# Altium schematic sheet mike_piezo.SchDoc
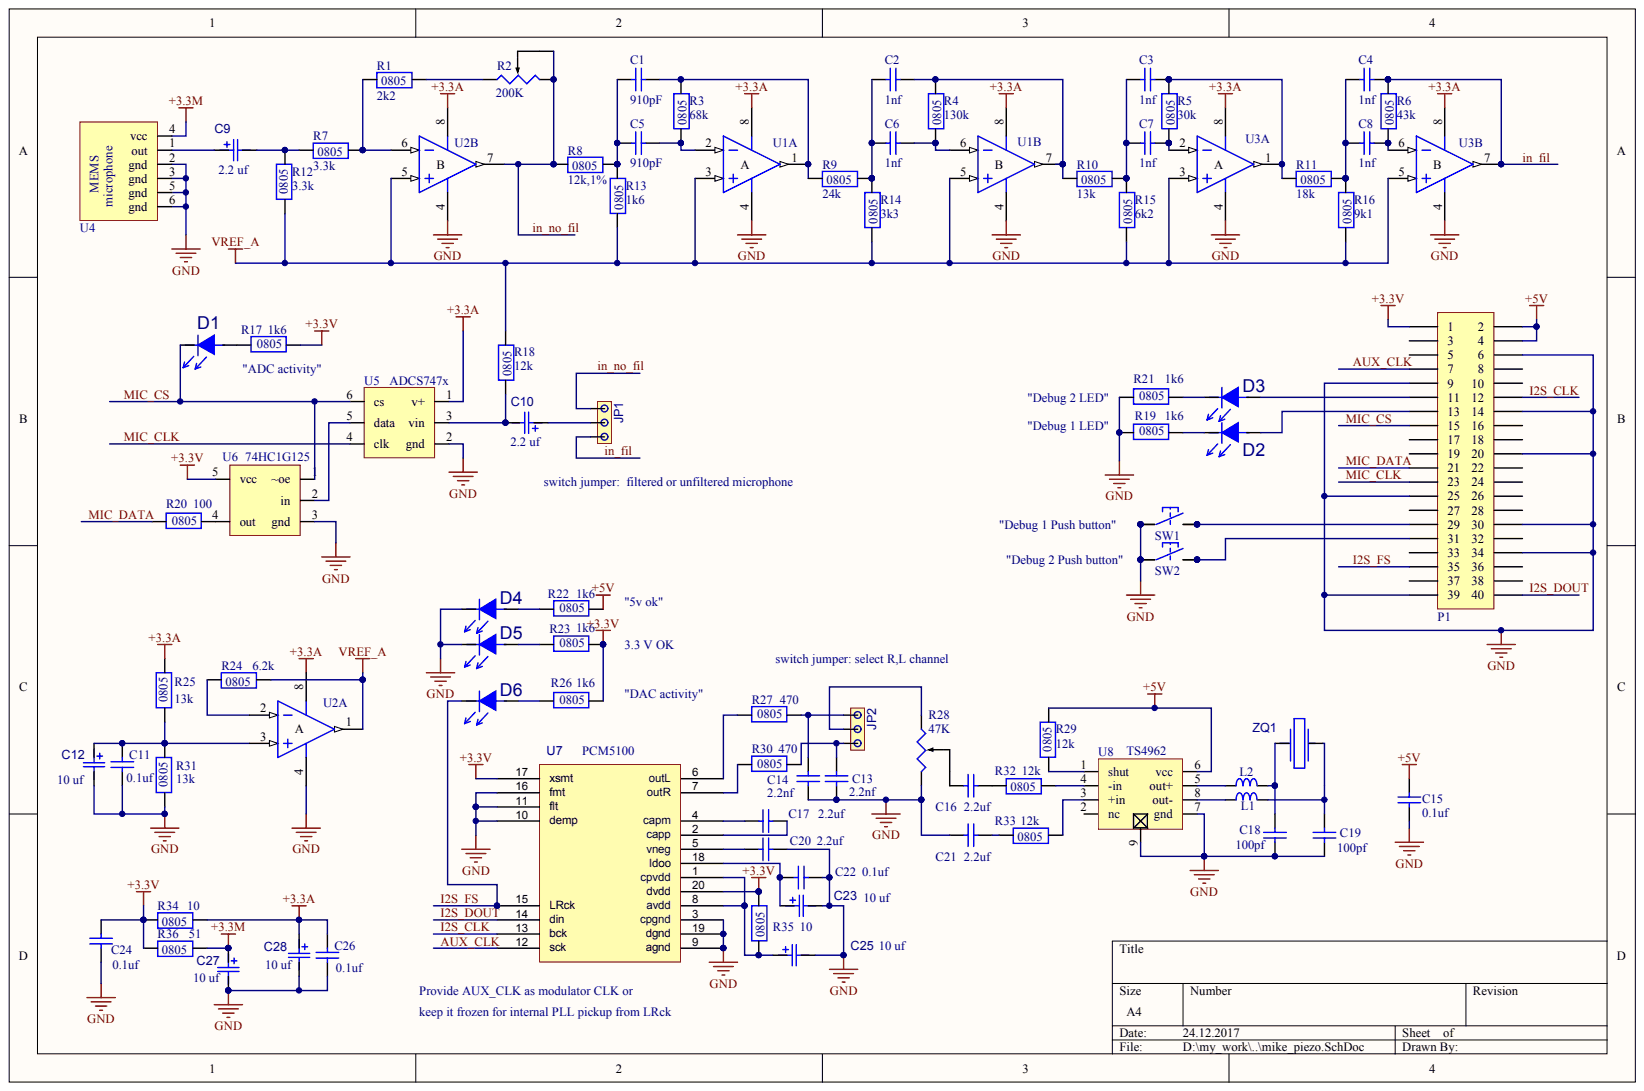
[diagram p0: mike_piezo.SchDoc]

## Parts
C1  value 910pF  (hidden comment: cap0805)
C2  value 1nf  (hidden comment: cap0805)
C3  value 1nf  (hidden comment: cap0805)
C4  value 1nf  (hidden comment: cap0805)
C5  value 910pF  (hidden comment: cap0805)
C6  value 1nf  (hidden comment: cap0805)
C7  value 1nf  (hidden comment: cap0805)
C8  value 1nf  (hidden comment: cap0805)
C9  CAP_SMT_d4x5.4  (value not drawn)
C10  CAP_SMT_d4x5.4  (value not drawn)
C11  value 0.1uf  (hidden comment: cap0805)
C12  CAP_POL_SMA  (value not drawn)
C13  value 2.2nf  (hidden comment: cap0805)
C14  value 2.2nf  (hidden comment: cap0805)
C15  value 0.1uf  (hidden comment: cap0805)
C16  value 2.2uf  (hidden comment: cap0805)
C17  value 2.2uf  (hidden comment: cap0805)
C18  value 100pf  (hidden comment: cap0805)
C19  value 100pf  (hidden comment: cap0805)
C20  value 2.2uf  (hidden comment: cap0805)
C21  value 2.2uf  (hidden comment: cap0805)
C22  value 0.1uf  (hidden comment: cap0805)
C23  CAP_POL_SMA  (value not drawn)
C24  value 0.1uf  (hidden comment: cap0805)
C25  CAP_POL_SMA  (value not drawn)
C26  value 0.1uf  (hidden comment: cap0805)
C27  CAP_POL_SMA  (value not drawn)
C28  CAP_POL_SMA  (value not drawn)
D1  LIGHT_SM0805  (value not drawn)
D2  LIGHT_SM0805  (value not drawn)
D3  LIGHT_SM0805  (value not drawn)
D4  LIGHT_SM0805  (value not drawn)
D5  LIGHT_SM0805  (value not drawn)
D6  LIGHT_SM0805  (value not drawn)
JP1  JUMPER3  (value not drawn)
JP2  JUMPER3  (value not drawn)
L1  75R@100MHz  [INDC2012X105N]  (value not drawn)
L2  75R@100MHz  [INDC2012X105N]  (value not drawn)
P1  2x20 2.54mm vertical  (value not drawn)
R1  value 2k2  (hidden comment: res0805_EU)
R2  value 200K  (hidden comment: res_var_SMT)
R3  value 68k  (hidden comment: res0805_EU)
R4  value 130k  (hidden comment: res0805_EU)
R5  value 30k  (hidden comment: res0805_EU)
R6  value 43k  (hidden comment: res0805_EU)
R7  value 3.3k  (hidden comment: res0805_EU)
R8  value 12k,1%  (hidden comment: res0805_EU)
R9  value 24k  (hidden comment: res0805_EU)
R10  value 13k  (hidden comment: res0805_EU)
R11  value 18k  (hidden comment: res0805_EU)
R12  value 3.3k  (hidden comment: res0805_EU)
R13  value 1k6  (hidden comment: res0805_EU)
R14  value 3k3  (hidden comment: res0805_EU)
R15  value 6k2  (hidden comment: res0805_EU)
R16  value 9k1  (hidden comment: res0805_EU)
R17  value 1k6  (hidden comment: res0805_EU)
R18  value 12k  (hidden comment: res0805_EU)
R19  value 1k6  (hidden comment: res0805_EU)
R20  value 100  (hidden comment: res0805_EU)
R21  value 1k6  (hidden comment: res0805_EU)
R22  value 1k6  (hidden comment: res0805_EU)
R23  value 1k6  (hidden comment: res0805_EU)
R24  value 6.2k  (hidden comment: res0805_EU)
R25  value 13k  (hidden comment: res0805_EU)
R26  value 1k6  (hidden comment: res0805_EU)
R27  value 470  (hidden comment: res0805_EU)
R28  value 47K  (hidden comment: res_var_SMT)
R29  value 12k  (hidden comment: res0805_EU)
R30  value 470  (hidden comment: res0805_EU)
R31  value 13k  (hidden comment: res0805_EU)
R32  value 12k  (hidden comment: res0805_EU)
R33  value 12k  (hidden comment: res0805_EU)
R34  value 10  (hidden comment: res0805_EU)
R35  value 10  (hidden comment: res0805_EU)
R36  value 51  (hidden comment: res0805_EU)
SW1  Button 6x6 SMT  (value not drawn)
SW2  Button 6x6 SMT  (value not drawn)
U1  AD8572ARZ  (value not drawn)  (parts A,B)
U2  AD8572ARZ  (value not drawn)  (parts A,B)
U3  AD8572ARZ  (value not drawn)  (parts A,B)
U4  microphone
U5  ADCS747x
U6  74HC1G125
U7  value PCM5100  (hidden comment: PCM510x)
U8  TS4962
ZQ1  Piezo  (value not drawn)

## Nets
+3.3A: C26.2 C28.1 R25.2 R34.1 U1.8 U2.8 U3.8 U5.1(v+)
+3.3M: C27.1 R36.1 U4.4(vcc)
+3.3V: C24.2 P1.1 R17.2 R23.2 R26.2 R34.2 R35.1 R36.2 U6.5(vcc) U7.17(xsmt) U7.20(dvdd)
+5V: C15.2 P1.2 P1.4 R22.2 R29.2 U8.6(vcc)
GND: C11.1 C12.2 C13.1 C14.1 C15.1 C18.1 C19.1 C20.2 C21.1 C22.2 C23.2 C24.1 C25.2 C26.1 C27.2 C28.2 D4.2 D5.2 P1.6 P1.9 P1.14 P1.20 P1.25 P1.30 P1.34 P1.39 R19.1 R21.1 R28.3 R31.1 SW1.1 SW1.2 SW2.1 SW2.2 U1.4 U2.4 U3.4 U4.2(gnd) U4.3(gnd) U4.5(gnd) U4.6(gnd) U5.2(gnd) U6.3(gnd) U7.3(cpgnd) U7.9(agnd) U7.10(demp) U7.11 U7.16(fmt) U7.19(dgnd) U8.7(gnd) U8.9
VREF_A: R12.1 R13.2 R14.2 R15.2 R16.2 R18.1 R24.1 U1.3 U1.5 U2.1 U2.5 U3.3 U3.5
AUX_CLK: P1.7 U7.12(sck)
I2S_CLK: P1.12 U7.13(bck)
I2S_DOUT: P1.40 U7.14(din)
I2S_FS: D6.2 P1.35 U7.15(LRck)
IN_FIL: C4.2 JP1.1 R6.1 U3.7
IN_NO_FIL: JP1.3 R2.2 R2.3 R8.2 U2.7
MIC_CLK: P1.23 U5.4(clk)
MIC_CS: D1.2 P1.15 U5.6(cs) U6.1(~oe)
MIC_DATA: P1.21 R20.1
NetC1_1: C1.1 C5.1 R8.1 R13.1
NetC1_2: C1.2 R3.1 R9.2 U1.1
NetC2_1: C2.1 C6.1 R9.1 R14.1
NetC2_2: C2.2 R4.1 R10.2 U1.7
NetC3_1: C3.1 C7.1 R10.1 R15.1
NetC3_2: C3.2 R5.1 R11.2 U3.1
NetC4_1: C4.1 C8.1 R11.1 R16.1
NetC5_2: C5.2 R3.2 U1.2
NetC6_2: C6.2 R4.2 U1.6
NetC7_2: C7.2 R5.2 U3.2
NetC8_2: C8.2 R6.2 U3.6
NetC9_1: C9.1 U4.1(out)
NetC9_2: C9.2 R7.2 R12.2
NetC10_1: C10.1 JP1.2
NetC10_2: C10.2 R18.2 U5.3(vin)
NetC11_2: C11.2 C12.1 R25.1 R31.2 U2.3
NetC13_2: C13.2 JP2.1 R30.2
NetC14_2: C14.2 JP2.3 R27.2
NetC16_1: C16.1 R28.2
NetC16_2: C16.2 R32.2
NetC17_1: C17.1 U7.4(capm)
NetC17_2: C17.2 U7.2(capp)
NetC18_2: C18.2 L2.2 ZQ1.2
NetC19_2: C19.2 L1.2 ZQ1.1
NetC20_1: C20.1 U7.5(vneg)
NetC21_2: C21.2 R33.2
NetC22_1: C22.1 C23.1 U7.18(ldoo)
NetC25_1: C25.1 R35.2 U7.1(cpvdd) U7.8(avdd)
NetD1_1: D1.1 R17.1
NetD2_1: D2.1 P1.13
NetD2_2: D2.2 R19.2
NetD3_1: D3.1 P1.11
NetD3_2: D3.2 R21.2
NetD4_1: D4.1 R22.1
NetD5_1: D5.1 R23.1
NetD6_1: D6.1 R26.1
NetJP2_2: JP2.2 R28.1
NetL1_1: L1.1 U8.8(out-)
NetL2_1: L2.1 U8.5(out+)
NetP1_29: P1.29 SW1.3 SW1.4
NetP1_31: P1.31 SW2.3 SW2.4
NetR1_1: R1.1 R2.1
NetR1_2: R1.2 R7.1 U2.6
NetR20_2: R20.2 U6.4(out)
NetR24_2: R24.2 U2.2
NetR27_1: R27.1 U7.6(outL)
NetR29_1: R29.1 U8.1(shut)
NetR30_1: R30.1 U7.7(outR)
NetR32_1: R32.1 U8.4(-in)
NetR33_1: R33.1 U8.3(+in)
NetU5_5: U5.5(data) U6.2(in)

Not connected: P1 3 5 8 10 16 17 18 19 22 24 26 27 28 32 33 36 37 38; U8 2(nc)

## Library data of the parts
L1 L2 = (no symbol name): Part Number: IND0805_MURATA_BLM21BB750SN1D; Part Description: SMD EMIFIL Suppression Chip Ferrite Bead; Manufacturer: MURATA; Manufacturer Part Number: BLM21BB750SN1D; Power: 700mA; Resistance: 0.16R; Tolerance: ±25%; Table: Inductors SMD; Component Kind: Standard; Component Type: Standard; Pin Count: 2; Value: 75R@100MHz; Status: None; Case: 0805; Mounted: Yes; Socket: No; SMD: Yes; ComponentHeight: 1.05mm; CreateDate: 12/21/2015; LatestRevisionDate: 12/21/2015; Author: S. Jafar
C1-C28 D1-D6 JP1 JP2 P1 R1-R36 SW1 SW2 U1-U8 ZQ1 = (no symbol name)
  C1-C8 C11 C13-C22 C24 C26: Published: 8-Jun-2000; LatestRevisionDate: 17-Jul-2002; LatestRevisionNote: Re-released for DXP Platform.; PackageReference: RAD-0.3; PackageDescription: Radial Cap, Thru-Hole; 2 Leads; 0.3 in Pin Spacing
  C9 C10: : 2.2 uf
  C12 C23 C25 C27 C28: : 10 uf
  D1-D6 JP1 JP2 ZQ1: Value: *
  P1: LatestRevisionDate: 17-Jul-2002; LatestRevisionNote: Re-released for DXP Platform.
  R1-R36: Published: 8-Jun-2000; LatestRevisionDate: 29-May-2009; LatestRevisionNote: IPC-7351 Footprint Added.; PackageReference: J1-0603; PackageDescription: Chip Resistor
  U1-U3: Published: 8-Jun-2000; LatestRevisionDate: 13-Apr-2006; LatestRevisionNote: IPC-7351 Footprint Added.; DatasheetVersion: REV. A; PackageVersion: 1998; PackageReference: R-8D; PackageDescription: 8-Pin Narrow Body Small Outline Integrated Circuit 1.27 mm Pitch; ComponentLink1Description: Manufacturer Link; ComponentLink1URL: http://www.analog.com/en/prod/0,,759_786_AD822%2C00.html; ComponentLink2Description: Datasheet; ComponentLink2URL: http://www.analog.com/UploadedFiles/Data_Sheets/146518556AD822_e.pdf; ComponentLink3Description: Discontinuation Notice; ComponentLink3URL: http://www.analog.com/UploadedFiles/Aerospace_Information/505817548385948813507767505obsolete.pdf
  C1-C8 C11 C13-C22 C24 C26 P1 R1-R36 U1-U3: Publisher: Altium Limited
